# Altium schematic sheet Protection_logic.SchDoc(Protection_logic)
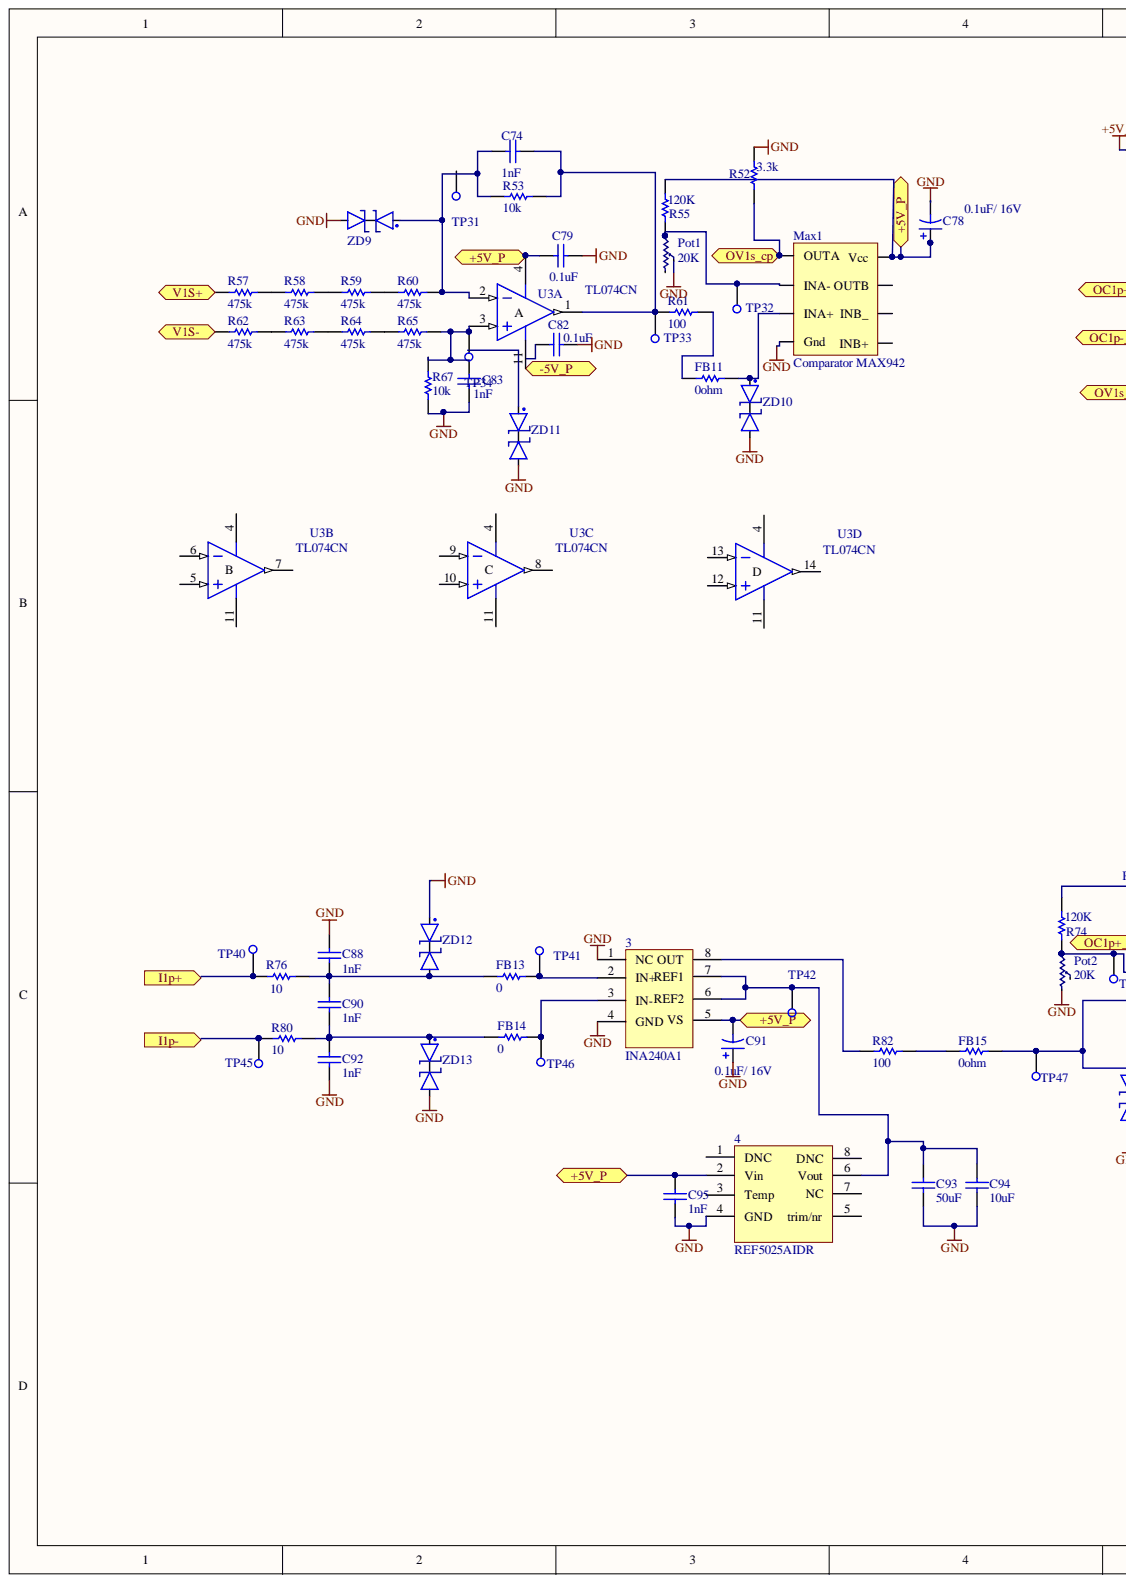
[diagram p0: Protection_logic.SchDoc, left]
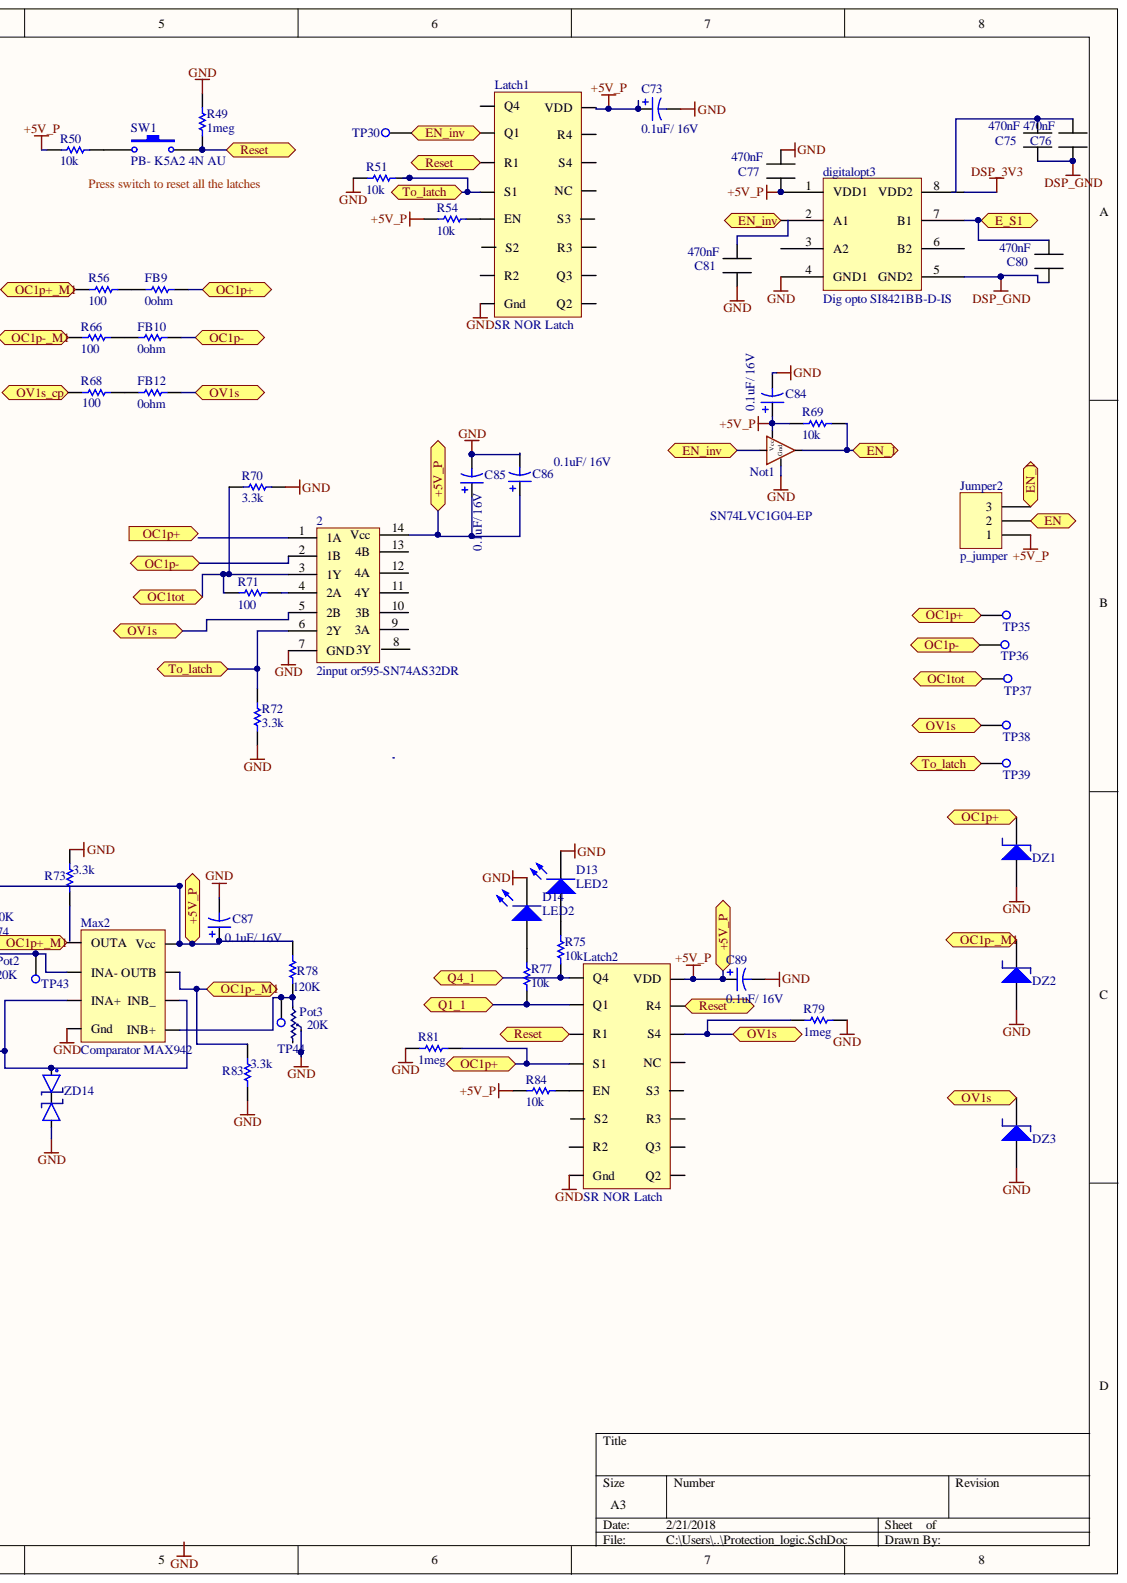
[diagram p1: Protection_logic.SchDoc, right]

## Parts
2  2input or595-SN74AS32DR  [2inputor]
3  INA240A1  [INA240]
4  REF5025AIDR  [REF52.5]
C73  value 0.1uF/ 16V  [C0805]  (hidden comment: Cap Pol3)
C74  value 1nF  [C1206]  (hidden comment: 16V)
C75  100nF  [Cap_SMD]  (value not drawn)
C76  100nF  [Cap_SMD]  (value not drawn)
C77  100nF  [Cap_SMD]  (value not drawn)
C78  value 0.1uF/ 16V  [C0805]  (hidden comment: Cap Pol3)
C79  value 0.1uF  [C1206]  (hidden comment: 16V)
C80  100nF  [Cap_SMD]  (value not drawn)
C81  100nF  [Cap_SMD]  (value not drawn)
C82  value 0.1uF  [C1206]  (hidden comment: 16V)
C83  value 1nF  [C1206]  (hidden comment: 16V)
C84  value 0.1uF/ 16V  [C0805]  (hidden comment: Cap Pol3)
C85  value 0.1uF/ 16V  [C0805]  (hidden comment: Cap Pol3)
C86  value 0.1uF/ 16V  [C0805]  (hidden comment: Cap Pol3)
C87  value 0.1uF/ 16V  [C0805]  (hidden comment: Cap Pol3)
C88  value 1nF  [C1206]  (hidden comment: 16V)
C89  value 0.1uF/ 16V  [C0805]  (hidden comment: Cap Pol3)
C90  value 1nF  [C1206]  (hidden comment: 16V)
C91  value 0.1uF/ 16V  [C0805]  (hidden comment: Cap Pol3)
C92  value 1nF  [C1206]  (hidden comment: 16V)
C93  value 50uF  [C1206]  (hidden comment: 16V)
C94  value 10uF  [C1206]  (hidden comment: 16V)
C95  value 1nF  [C1206]  (hidden comment: 16V)
D13  LED2  [3.2X1.6X1.1]
D14  LED2  [3.2X1.6X1.1]
digitalopt3  Dig opto SI8421BB-D-IS  [SO8 TS27l2]
DZ1  MMSZ5221BS  [MMSZ5221BS]  (value not drawn)
DZ2  MMSZ5221BS  [MMSZ5221BS]  (value not drawn)
DZ3  MMSZ5221BS  [MMSZ5221BS]  (value not drawn)
FB9  res_smd  [res_smd]  (value not drawn)
FB10  res_smd  [res_smd]  (value not drawn)
FB11  res_smd  [res_smd]  (value not drawn)
FB12  res_smd  [res_smd]  (value not drawn)
FB13  res_smd  [res_smd]  (value not drawn)
FB14  res_smd  [res_smd]  (value not drawn)
FB15  res_smd  [res_smd]  (value not drawn)
Jumper2  p_jumper  [Header1*3]
Latch1  SR NOR Latch  [SR latch]
Latch2  SR NOR Latch  [SR latch]
Max1  Comparator MAX942  [SOIC 8_ no EP]
Max2  Comparator MAX942  [SOIC 8_ no EP]
Not1  SN74LVC1G04-EP  [Inverter]
Pot1  20K  [Pot]
Pot2  20K  [Pot]
Pot3  20K  [Pot]
R49  res_smd  [res_smd]  (value not drawn)
R50  res_smd  [res_smd]  (value not drawn)
R51  res_smd  [res_smd]  (value not drawn)
R52  res_smd  [res_smd]  (value not drawn)
R53  res_smd  [res_smd]  (value not drawn)
R54  res_smd  [res_smd]  (value not drawn)
R55  res_smd  [res_smd]  (value not drawn)
R56  res_smd  [res_smd]  (value not drawn)
R57  res_smd  [res_smd]  (value not drawn)
R58  res_smd  [res_smd]  (value not drawn)
R59  res_smd  [res_smd]  (value not drawn)
R60  res_smd  [res_smd]  (value not drawn)
R61  res_smd  [res_smd]  (value not drawn)
R62  res_smd  [res_smd]  (value not drawn)
R63  res_smd  [res_smd]  (value not drawn)
R64  res_smd  [res_smd]  (value not drawn)
R65  res_smd  [res_smd]  (value not drawn)
R66  res_smd  [res_smd]  (value not drawn)
R67  res_smd  [res_smd]  (value not drawn)
R68  res_smd  [res_smd]  (value not drawn)
R69  res_smd  [res_smd]  (value not drawn)
R70  res_smd  [res_smd]  (value not drawn)
R71  res_smd  [res_smd]  (value not drawn)
R72  res_smd  [res_smd]  (value not drawn)
R73  res_smd  [res_smd]  (value not drawn)
R74  res_smd  [res_smd]  (value not drawn)
R75  res_smd  [res_smd]  (value not drawn)
R76  res_smd  [res_smd]  (value not drawn)
R77  res_smd  [res_smd]  (value not drawn)
R78  res_smd  [res_smd]  (value not drawn)
R79  res_smd  [res_smd]  (value not drawn)
R80  res_smd  [res_smd]  (value not drawn)
R81  res_smd  [res_smd]  (value not drawn)
R82  res_smd  [res_smd]  (value not drawn)
R83  res_smd  [res_smd]  (value not drawn)
R84  res_smd  [res_smd]  (value not drawn)
SW1  PB- K5A2 4N AU  [Push Button _New]
TP30  Test Point  [Test Point]  (value not drawn)
TP31  Test Point  [Test Point]  (value not drawn)
TP32  Test Point  [Test Point]  (value not drawn)
TP33  Test Point  [Test Point]  (value not drawn)
TP34  Test Point  [Test Point]  (value not drawn)
TP35  Test Point  [Test Point]  (value not drawn)
TP36  Test Point  [Test Point]  (value not drawn)
TP37  Test Point  [Test Point]  (value not drawn)
TP38  Test Point  [Test Point]  (value not drawn)
TP39  Test Point  [Test Point]  (value not drawn)
TP40  Test Point  [Test Point]  (value not drawn)
TP41  Test Point  [Test Point]  (value not drawn)
TP42  Test Point  [Test Point]  (value not drawn)
TP43  Test Point  [Test Point]  (value not drawn)
TP44  Test Point  [Test Point]  (value not drawn)
TP45  Test Point  [Test Point]  (value not drawn)
TP46  Test Point  [Test Point]  (value not drawn)
TP47  Test Point  [Test Point]  (value not drawn)
U3  TL074CN  [DIP14]  (parts A,B,C,D)
ZD9  8.2 v  [Zener Diode]  (value not drawn)
ZD10  8.2 v  [Zener Diode]  (value not drawn)
ZD11  8.2 v  [Zener Diode]  (value not drawn)
ZD12  8.2 v  [Zener Diode]  (value not drawn)
ZD13  8.2 v  [Zener Diode]  (value not drawn)
ZD14  8.2 v  [Zener Diode]  (value not drawn)

## Ports
+5V_P, -5V_P, E_S1, EN, EN_1, EN_inv, I1p+, I1p-, OC1p+, OC1p+_M1, OC1p-, OC1p-_M1, OC1tot, OV1s, OV1s_cp, Q1_1, Q4_1, Reset, To_latch, V1S+, V1S-

## Nets
DSP_3V3: digitalopt3.8(VDD2)
DSP_GND: C75.2 C76.2 C80.2 digitalopt3.5(GND2)
GND: 2.7(GND) 3.1(NC) 3.4(GND) 4.4(GND) C73.2 C77.1 C78.2 C79.1 C81.2 C82.1 C83.2 C84.2 C85.2 C86.2 C87.2 C88.2 C89.2 C91.1 C92.1 C93.1 C94.1 C95.1 D13.2 D14.2 digitalopt3.4(GND1) DZ1.2 DZ2.2 DZ3.2 Latch1.8(Gnd) Latch2.8(Gnd) Max1.4(Gnd) Max2.4(Gnd) Not1.3(Gnd) Pot1.2 Pot2.3 Pot3.2 R49.1 R51.2 R52.2 R67.2 R70.2 R72.1 R73.2 R79.1 R81.2 R83.1 ZD9.2 ZD10.2 ZD11.2 ZD12.1 ZD13.2 ZD14.2
+5V_P: 2.14(Vcc) 3.5(VS) 4.2(Vin) C73.1 C77.2 C78.1 C79.2 C84.1 C85.1 C86.1 C87.1 C89.1 C91.2 C95.2 digitalopt3.1(VDD1) Jumper2.1 Latch1.16(VDD) Latch2.16(VDD) Max1.8(Vcc) Max2.8(Vcc) Not1.5(Vcc) R50.2 R54.2 R55.2 R69.2 R74.2 R78.2 R84.2 U3.4 ; port +5V_P
E_S1: C80.1 digitalopt3.7(B1) ; port E_S1
EN: Jumper2.2 ; port EN
EN_1: Jumper2.3 Not1.4 R69.1 ; port EN_1
EN_inv: digitalopt3.2(A1) Latch1.2(Q1) Not1.2 TP30.1 ; port EN_inv
OC1tot: 2.3(1Y) R70.1 R71.2 TP37.1 ; port OC1tot
OV1s: 2.5(2B) DZ3.1 FB12.1 Latch2.14(S4) R79.2 TP38.1 ; port OV1s
OV1s_cp: Max1.1(OUTA) R52.1 R68.2 ; port OV1s_cp
Q1_1: Latch2.2(Q1) R77.1 ; port Q1_1
Q4_1: Latch2.1(Q4) R75.1 ; port Q4_1
Reset: Latch1.3(R1) Latch2.3(R1) Latch2.15(R4) R49.2 SW1.2 ; port Reset
To_latch: 2.6(2Y) Latch1.4(S1) R51.1 R72.2 TP39.1 ; port To_latch
Net2_2: 2.2(1B) FB10.1 TP36.1
Net2_4: 2.4(2A) R71.1
Net3_2: 3.2(IN+) FB13.1 TP41.1
Net3_3: 3.3(IN-) FB14.1 TP46.1
Net3_6: 3.6(REF2) 3.7(REF1) 4.6(Vout) C93.2 C94.2 TP42.1
Net3_8: 3.8(OUT) R82.2
NetC74_1: C74.1 R53.1 R61.2 TP33.1 U3.1
NetC74_2: C74.2 R53.2 R60.1 U3.2 ZD9.1
NetC75_1: C75.1 C76.1
NetC83_1: C83.1 R65.1 R67.1 TP34.1 U3.3
NetC88_1: C88.1 C90.2 FB13.2 R76.1 ZD12.2
NetC90_1: C90.1 C92.2 FB14.2 R80.1 ZD13.1
NetD13_1: D13.1 R75.2
NetD14_1: D14.1 R77.2
NetDZ1_1: DZ1.1 FB9.1 Latch2.4(S1) R81.1 TP35.1
NetDZ2_1: DZ2.1 Max2.7(OUTB) R66.2 R83.2
NetFB9_2: FB9.2 R56.1
NetFB10_2: FB10.2 R66.1
NetFB11_1: FB11.1 Max1.3(INA+) ZD10.1
NetFB11_2: FB11.2 R61.1
NetFB12_2: FB12.2 R68.1
NetFB15_1: FB15.1 Max2.3(INA+) Max2.6(INB_) TP47.1 ZD14.1
NetFB15_2: FB15.2 R82.1
NetLatch1_5: Latch1.5(EN) R54.1
NetLatch2_5: Latch2.5(EN) R84.1
NetMax1_2: Max1.2(INA-) Pot1.1 R55.1 TP32.1
NetMax1_5: Max1.5(INB+)
NetMax2_1: Max2.1(OUTA) R56.2
NetMax2_2: Max2.2(INA-) Pot2.1 R74.1 TP43.1
NetMax2_5: Max2.5(INB+) Pot3.1 R78.1 TP44.1
NetR50_1: R50.1 SW1.1
NetR57_1: R57.1 R58.2
NetR57_2: R57.2
NetR58_1: R58.1 R59.2
NetR59_1: R59.1 R60.2
NetR62_1: R62.1 R63.2
NetR62_2: R62.2
NetR63_1: R63.1 R64.2
NetR64_1: R64.1 R65.2
NetR73_1: R73.1
NetR76_2: R76.2 TP40.1
NetR80_2: R80.2 TP45.1
NetU3_11: U3.11
N00174:
N00176:
N00177:

Not connected: 2 1(1A) 8(3Y) 9(3A) 10(3B) 11(4Y) 12(4A) 13(4B); 4 1(DNC) 3(Temp) 5(trim/nr) 7(NC) 8(DNC); C81 1; C82 2; digitalopt3 3(A2) 6(B2); Latch1 1(Q4) 6(S2) 7(R2) 9(Q2) 10(Q3) 11(R3) 12(S3) 13(NC) 14(S4) 15(R4); Latch2 6(S2) 7(R2) 9(Q2) 10(Q3) 11(R3) 12(S3) 13(NC); Max1 6(INB_) 7(OUTB); Pot1 3; Pot2 2; Pot3 3; TP31 1; U3 5 6 7 8 9 10 12 13 14; ZD9 3; ZD10 3; ZD11 1 3; ZD12 3; ZD13 3; ZD14 3

## Library data of the parts
2 = 2 input OR: Component Kind: Standard; Library Name: Gan_cuk converter.SchLib
3 = ina240: GAIN 20; Component Kind: Standard; Library Name: Gan_cuk converter.SchLib
C73 C78 C84-C87 C89 C91 = Cap Pol3: Polarized Capacitor (Surface Mount); Simulation: CAP; Signal Integrity: Cap Pol; Published: 8-Jun-2000; PackageReference: C0805; PackageDescription: Chip Capacitor; Library Name: Miscellaneous Devices.SchLib; LatestRevisionNote: IPC-7351 Footprint Added.; LatestRevisionDate: 29-May-2009; Component Kind: Standard; Publisher: Altium Limited
C74 C79 C82 C83 C88 C90 C92-C95 = Cap Semi: Capacitor (Semiconductor SIM Model); Simulation: CAP; Signal Integrity: Cap Semi; Published: 8-Jun-2000; PackageReference: C1206; PackageDescription: Chip Capacitor; Library Name: Miscellaneous Devices.SchLib; LatestRevisionNote: IPC-7351 Footprint Added.; LatestRevisionDate: 29-May-2009; Component Kind: Standard; Publisher: Altium Limited
C75-C77 C80 C81 = Cap_SMD: Library Name: Schlib1.SchLib; Component Kind: Standard; : 470nF
D13 D14 = LED2: Typical RED, GREEN, YELLOW, AMBER GaAs LED; Simulation: LED2; Published: 8-Jun-2000; PackageVersion: Feb-2002; PackageReference: 3.2X1.6X1.1; PackageDescription: SM LED; 2 Flat Leads; Library Name: Miscellaneous Devices.IntLib; LatestRevisionNote: Re-released for DXP Platform.; LatestRevisionDate: 17-Jul-2002; Component Kind: Standard; Publisher: Altium Limited
digitalopt3 = Digital Isolator: Library Name: control Board.SchLib; Component Kind: Standard
FB9-FB15 R49-R84 = res_smd: smd_res; Library Name: Schlib1.SchLib; Component Kind: Standard
  FB9-FB12 FB15: : 0ohm
  FB13 FB14: : 0
  R49 R79 R81: : 1meg
  R50 R51 R53 R54 R67 R69 R75 R77 R84: : 10k
  R52 R70 R72 R73 R83: : 3.3k
  R55 R74 R78: : 120K
  R56 R61 R66 R68 R71 R82: : 100
  R57-R60 R62-R65: : 475k
  R76 R80: : 10
Latch1 Latch2 = SR Latch: CD4043BDR; Component Kind: Standard; Library Name: Gan_cuk converter.SchLib
Max1 Max2 = Max942: MAX942ESA+; Component Kind: Standard; Library Name: Gan_cuk converter.SchLib
Not1 = Inverter: Description: SN74LVC1G04-EP; Component Kind: Standard; Library Name: Gan_cuk converter.SchLib
Pot1-Pot3 = TRIM POT: 3296W-1-253LF; Component Kind: Standard; Library Name: Gan_cuk converter.SchLib
SW1 = SW-PB: Switch; Revision: July-2002: Re-released for DXP Platform.; Published: 24-Mar-1999; Library Name: control Board.SchLib; Component Kind: Standard; Publisher: Altium Limited
U3 = TL074J: Low-Noise JFET-Input Operational Amplifier; Simulation: TL074; Published: 16-Jan-2001; PCB3D: J014; PackageVersion: Jun-1999; PackageReference: J014; PackageDescription: DIP; 14 Leads; Row Spacing 7.62 mm; Pitch 2.54 mm; LatestRevisionNote: Stylized 3D Model Added.; LatestRevisionDate: 04-Mar-2005; DatasheetVersion: Aug-1996; ComponentLink2URL: http://www-s.ti.com/sc/ds/tl074.pdf; ComponentLink2Description: Datasheet; ComponentLink1URL: http://www.ti.com/; ComponentLink1Description: Manufacturer Link; Component Kind: Standard; Publisher: Altium Limited; Library Name: Cuk_3Ph_Control.IntLib
ZD9-ZD14 = Zener_diode: DZ23C8V2-7-F; Component Kind: Standard; Library Name: Gan_cuk converter.SchLib
4 = REF5025AIDR: Component Kind: Standard; Library Name: Gan_cuk converter.SchLib
DZ1-DZ3 = MMSZ5221BS: Description: MMSZ5221BS; Component Kind: Standard; Library Name: lib_ankit.SchLib
Jumper2 = p_jumper: Component Kind: Standard; Library Name: lib_ankit.SchLib
TP30-TP47 = Test Point: Component Kind: Standard; Library Name: Gan_cuk converter.SchLib
